# Altium schematic sheet Sheet2.SchDoc(Sheet2)
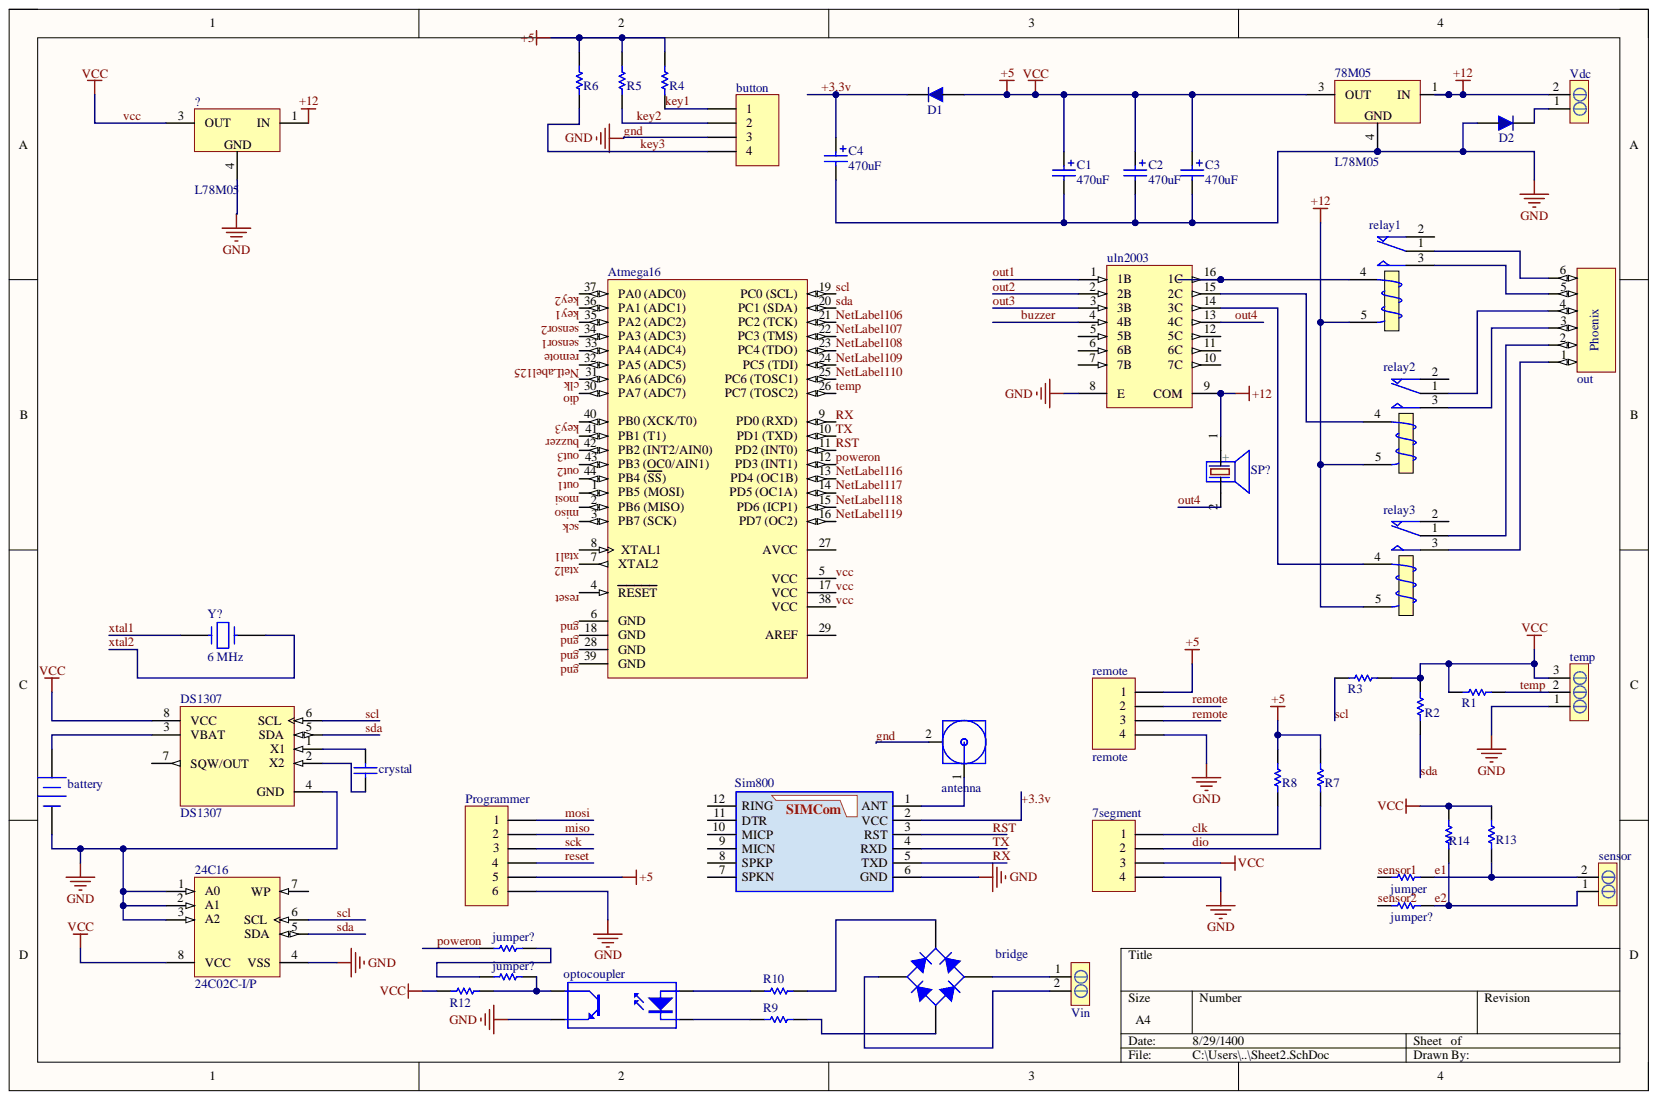
[diagram p0: Sheet2.SchDoc]

## Parts
7segment  7segment  [HDR1X4]
24C16  24C02C-I/P  [PDIP300-P8]
78M05  L78M05  [TO-252]
?  L78M05  [TO-252]
antenna  ANTENNA  [SMA-Right]  (value not drawn)
Atmega16  ATmega16-16AU  [44A_M]  (value not drawn)
battery  BATTERY 3V 1220  [BATT SMD]  (value not drawn)
bridge  Bridge KBU PACKAGE  [Bridge DB107 Package]  (value not drawn)
button  button  [HDR1X4]
C1  470uF  [CAP_POL5]
C2  470uF  [CAP_POL5]
C3  470uF  [CAP_POL5]
C4  470uF  [CAP_POL5]
crystal  22pF  [XTAL-DT26]  (value not drawn)
D1  1n4007  [DIOM4629X24N]  (value not drawn)
D2  1n4007  [DIOM4629X24N]  (value not drawn)
DS1307  DS1307  [DIP8]
jumper  10K  [AXIAL-0.2]  (value not drawn)
jumper?  10K  [AXIAL-0.2]  (value not drawn)
jumper?  10K  [AXIAL-0.2]  (value not drawn)
jumper?  10K  [AXIAL-0.2]  (value not drawn)
optocoupler  Optoisolator1  [DIP-4]  (value not drawn)
out  PHOENIX 6  [phonix 6]  (value not drawn)
Programmer  programmer  [HDR1X6]  (value not drawn)
R1  RES  [CC2012-0805]  (value not drawn)
R2  RES  [CC2012-0805]  (value not drawn)
R3  RES  [CC2012-0805]  (value not drawn)
R4  RES  [CC2012-0805]  (value not drawn)
R5  RES  [CC2012-0805]  (value not drawn)
R6  RES  [CC2012-0805]  (value not drawn)
R7  RES  [CC2012-0805]  (value not drawn)
R8  RES  [CC2012-0805]  (value not drawn)
R9  1K  [Axial 1W  7_0mm]  (value not drawn)
R10  1K  [Axial 1W  7_0mm]  (value not drawn)
R12  RES  [CC2012-0805]  (value not drawn)
R13  RES  [CC2012-0805]  (value not drawn)
R14  RES  [CC2012-0805]  (value not drawn)
relay1  (no value)  [Relay 18.85x15.35x15.70]
relay2  (no value)  [Relay 18.85x15.35x15.70]
relay3  (no value)  [Relay 18.85x15.35x15.70]
remote  remote  [HDR1X4]
sensor  PHONIX2  [PHONIX2]  (value not drawn)
Sim800  SIM800L  [SIM800L_L250W236H60]  (value not drawn)
SP?  (no value)  [BUZZER 7.62mm DC]
temp  PHONIX3  [PHONIX3]  (value not drawn)
uln2003  ULN2003AN  [N0016A]  (value not drawn)
Vdc  PHONIX2  [PHONIX2]  (value not drawn)
Vin  PHONIX2  [PHONIX2]  (value not drawn)
Y?  6 MHz  [My_Crystal]

## Nets
+12: 78M05.1 ?.1 relay1.5 relay2.5 relay3.5 SP?.1 uln2003.9(COM) Vdc.2
+3.3v: C4.1 D1.2 Sim800.2(VCC)
buzzer: Atmega16.41(PB1_(T1)) uln2003.4(4B)
clk: 7segment.1 Atmega16.31(PA6_(ADC6)) R8.1
dio: 7segment.2 Atmega16.30(PA7_(ADC7)) R7.1
e1: jumper.2 R13.1 sensor.2
e2: jumper?.2 R14.1 sensor.1
gnd: 7segment.4 24C16.1(A0) 24C16.2(A1) 24C16.3(A2) 24C16.4(VSS) 78M05.4 ?.4 antenna.2 Atmega16.6(GND) Atmega16.18(GND) Atmega16.28(GND) Atmega16.39(GND) battery.2 button.3 C1.2 C2.2 C3.2 C4.2 D2.1 DS1307.4(GND) optocoupler.3 Programmer.6 remote.4 Sim800.6(GND) temp.1 uln2003.8(E)
key1: Atmega16.36(PA1_(ADC1)) button.1 R4.1
key2: Atmega16.37(PA0_(ADC0)) button.2 R5.1
key3: Atmega16.40(PB0_(XCK/T0)) button.4 R6.1
miso: Atmega16.2(PB6_(MISO)) Programmer.2
mosi: Atmega16.1(PB5_(MOSI)) Programmer.1
NetLabel106: Atmega16.21(PC2_(TCK))
NetLabel107: Atmega16.22(PC3_(TMS))
NetLabel108: Atmega16.23(PC4_(TDO))
NetLabel109: Atmega16.24(PC5_(TDI))
NetLabel110: Atmega16.25(PC6_(TOSC1))
NetLabel116: Atmega16.13(PD4_(OC1B))
NetLabel117: Atmega16.14(PD5_(OC1A))
NetLabel118: Atmega16.15(PD6_(ICP1))
NetLabel119: Atmega16.16(PD7_(OC2))
NetLabel125: Atmega16.32(PA5_(ADC5))
out1: Atmega16.44(PB4_(SS)) uln2003.1(1B)
out2: Atmega16.43(PB3_(OC0/AIN1)) uln2003.2(2B)
out3: Atmega16.42(PB2_(INT2/AIN0)) uln2003.3(3B)
out4: SP?.2 uln2003.13(4C)
poweron: Atmega16.12(PD3_(INT1)) jumper?.1
remote: Atmega16.33(PA4_(ADC4)) remote.2 remote.3
reset: Atmega16.4(RESET) Programmer.4
RST: Atmega16.11(PD2_(INT0)) Sim800.3(RST)
RX: Atmega16.9(PD0_(RXD)) Sim800.5(TXD)
sck: Atmega16.3(PB7_(SCK)) Programmer.3
scl: 24C16.6(SCL) Atmega16.19(PC0_(SCL)) DS1307.6(SCL) R3.1
sda: 24C16.5(SDA) Atmega16.20(PC1_(SDA)) DS1307.5(SDA) R2.1
sensor1: Atmega16.34(PA3_(ADC3)) jumper.1
sensor2: Atmega16.35(PA2_(ADC2)) jumper?.1
temp: Atmega16.26(PC7_(TOSC2)) R1.2 temp.2
TX: Atmega16.10(PD1_(TXD)) Sim800.4(RXD)
vcc: 7segment.3 24C16.8(VCC) 78M05.3 ?.3 Atmega16.5(VCC) Atmega16.17(VCC) Atmega16.38(VCC) C1.1 C2.1 C3.1 D1.1 DS1307.8(VCC) Programmer.5 R1.1 R2.2 R3.2 R4.2 R5.2 R6.2 R7.2 R8.2 R12.2 R13.2 R14.2 remote.1 temp.3
xtal1: Atmega16.8(XTAL1) Y?.1
xtal2: Atmega16.7(XTAL2) Y?.2
Netantenna_1: antenna.1 Sim800.1(ANT)
Netbattery_1: battery.1 DS1307.3(VBAT)
Netbridge_1: bridge.1 R10.2
Netbridge_2: bridge.2 Vin.2
Netbridge_3: bridge.3 Vin.1
Netbridge_4: bridge.4 R9.2
Netcrystal_1: crystal.1 DS1307.1(X1)
Netcrystal_2: crystal.2 DS1307.2(X2)
NetD2_2: D2.2 Vdc.1
Netjumper?_1: jumper?.1 jumper?.2
Netjumper?_2: jumper?.2 optocoupler.4 R12.1
Netoptocoupler_1: optocoupler.1 R10.1
Netoptocoupler_2: optocoupler.2 R9.1
Netout_1: out.1 relay3.3
Netout_2: out.2 relay3.1
Netout_3: out.3 relay2.3
Netout_4: out.4 relay2.1
Netout_5: out.5 relay1.3
Netout_6: out.6 relay1.1
Netrelay1_4: relay1.4 uln2003.16(1C)
Netrelay2_4: relay2.4 uln2003.15(2C)
Netrelay3_4: relay3.4 uln2003.14(3C)

Not connected: 24C16 7(WP); Atmega16 27(AVCC) 29(AREF); DS1307 7(SQW/OUT); relay1 2; relay2 2; relay3 2; Sim800 7(SPKN) 8(SPKP) 9(MICN) 10(MICP) 11(DTR) 12(RING); uln2003 5(5B) 6(6B) 7(7B) 10(7C) 11(6C) 12(5C)

## Library data of the parts
7segment button remote = Header 4: Header, 4-Pin; Component Kind: Standard; LatestRevisionDate: 17-Jul-2002; LatestRevisionNote: Re-released for DXP Platform.; Library Name: Altium.IntLib; Signal Integrity: Connector; Publisher: Altium Limited
24C16 = CMP-0159-00018-1: 2Kbit, 400kHz, 5V, I2C Serial EEPROM, 8-Pin PDIP, Industrial Temperature; Code_JEDEC: MS-001; Component Kind: Standard; DatasheetVersion: revJ, Aug-2008; Library Name: Altium Content Vault; PackageDescription: 8-Lead Plastic Dual In-Line (P) - 300 mil Body [PDIP]; PackageReference: PDIP300-P8; PackageVersion: revBB, Aug-2009
78M05 ? = L78M05: Precision 500mA Regulator; Component Kind: Standard; Library Name: Altium.IntLib
Atmega16 = CMP-0095-00235-2: 8-bit AVR Microcontroller, 4.5-5.5V, 16KB Flash, 512 Bytes EEPROM, 1KB SRAM, 44-pin TQFP, Industrial Grade (-40°C to 85°C), Pb-Free; Code_JEDEC: MS-026-ACB; Component Kind: Standard; ComponentLink1Description: Manufacturer URL; ComponentLink1URL: http://www.atmel.com/; ComponentLink2Description: ATmega16(L)  Datasheet; ComponentLink2URL: http://www.atmel.com/dyn/resources/prod_documents/doc2466.pdf; ComponentLink3Description: ATmega16(L) Summary; ComponentLink3URL: http://www.atmel.com/dyn/resources/prod_documents/2466S.pdf; DatasheetVersion: revT, Jul-2010; Library Name: Altium Content Vault; PackageDescription: 44-Pin Plastic Thin Profile Quad Flat Pack, 10 x 10 x 1.0 mm Body, 0.8 mm Pitch (TQFP); PackageReference: 44A; PackageVersion: revC, Oct-2010; RevisionNote: DatasheetVersion & PackageVersion updated. URLs added.
C1-C4 = Cap Pol3: Polarized Capacitor (Surface Mount); Value: 100pF; Component Kind: Standard; Library Name: General_15.IntLib; Published: 8-Jun-2000; Revision: July-2002: Re-released for DXP Platform.; Publisher: Altium Limited
crystal = Cap Semi_1: Capacitor (Semiconductor SIM Model); Component Kind: Standard; LatestRevisionDate: 29-May-2009; LatestRevisionNote: IPC-7351 Footprint Added.; Library Name: Devices.IntLib; PackageDescription: Chip Capacitor; PackageReference: C1206; Published: 8-Jun-2000; Signal Integrity: Cap Semi; Simulation: CAP; Value: 22pF; Publisher: Altium Limited
D1 D2 = 1n4007 SMD M7: Standard Rectifiers; Manufacturer: DC COMPONENTS; Part. No: M7; Designer: Abbas Basereh; Component Kind: Standard; Data: 16-12-2016; Library Name: Altium.IntLib; Link: http://www.tme.eu/en/details/m7/smd-universal-diodes/dc-components/
DS1307 = DS1307: 64 X 8 Serial Real-Time Clock; Package Information: Latest Revision: 1999; Component Kind: Standard; Datasheet: Latest Revision: 10-Aug-1999; Library Name: General_15.IntLib; Package Reference: DIP8; Published: 13-Oct-2000; Revision: 17-Jul-2002: Re-released for DXP Platform.; Publisher: Altium Limited
jumper jumper? jumper? jumper? = Res1: Resistor; Value: 1K; Component Kind: Standard; Library Name: General_15.IntLib; Published: 8-Jun-2000; Revision: July-2002: Re-released for DXP Platform.; Publisher: Altium Limited
optocoupler = Optoisolator1: 4-Pin Phototransistor Optocoupler; Component Kind: Standard; DatasheetVersion: Nov-2003; LatestRevisionDate: 04-Mar-2005; LatestRevisionNote: Sch pins 3 & 4 Swapped.; Library Name: Miscellaneous Devices.IntLib; PackageReference: DIP-4; Published: 24-Mar-1999; Publisher: Altium Limited
Programmer = Header 6: Header, 6-Pin; Component Kind: Standard; LatestRevisionDate: 17-Jul-2002; LatestRevisionNote: Re-released for DXP Platform.; Library Name: Altium.IntLib; Signal Integrity: Connector; Publisher: Altium Limited
R1-R8 R12-R14 = RES: Resistor; Component Kind: Standard; DatasheetDocument: Nov-2002; Published: 5-Jan-2004; Library Name: Altium Nanoboard Project.IntLib; Publisher: Altium Limited
R9 R10 = RES Axial 1W: Designer: Abbas Basereh; Component Kind: Standard; Data: 4-1-2017; Desc.: RES Axial 1W; Description: RES Axial 1W; Library Name: General_14.IntLib
relay1-relay3 = RELAY_SPDT: Single-Pole Dual-Throw Relay; Designer: Abbas Basereh; Component Kind: Standard; ComponentLink1Description: Datasheet; Library Name: Altium.IntLib; Mounting Technology: Through-Hole; Pin Count: 0; Update Date: 2017-3-1
Sim800 = SIM800L: GSM/GPRS Module; Component Kind: Standard; Library Name: SIM800L.SchLib; Publisher: 3DFootprint.ir
SP? = PIEZO BUZZER: PIEZO BUZZER ACTIVE; Comment_1: SPEAKER AND BEEP; Designer: Abbas Basereh; Component Kind: Standard; ComponentLink2Description: Reference; Design Date: 1395/11/14; Library Name: Altium.IntLib; Mounting Technology: THD; PackageDescription: THD; Pin Count: 2; Status: Unreleased; Publisher: Abbas Basereh
uln2003 = CMP-2000-07352-1: High-Voltage, High-Current Darlington Transistor Array, 50 V, 500 mA, -20 to 70 degC, 16-Pin PDIP (N), Pb-Free (RoHS), Tube; Manufacturer: TexasInstruments; Configuration: Array7; Maximum Collector Emitter Saturation Voltage: 1.1@100mA; Maximum Collector Emitter Voltage: 50V; Package Version: 4040049/E, 12/2002; Peak DC Collector Current: 0.5A; Rad Hard: No; Category: DarlingtonBJT; Component Kind: Standard; Datasheet URL: http://www.ti.com/general/docs/lit/getliterature.tsp?genericPartNumber=uln2003a&fileType=pdf; Datasheet Version: Rev. O, 01/2016; Library Name: Altium Content Vault; Manufacturer URL: http://www.ti.com/; Mounting: ThroughHole; Mounting Technology: ThroughHole; Package: 16PDIP; Package Description: 16-Pin Plastic In-Line, Body 19.305 x 6.35 mm, Pitch 2.54 mm; Package Reference: N16; Package URL: http://www.ti.com/litv/pdf/mpdi002c; Packaging: Rail/Tube; Type: NPN
Y? = CRYSTAL: Component Kind: Standard; Description: Crystal; Library Name: Devices.IntLib
antenna = ANTENNA: Component Kind: Standard; Library Name: ZetaBoard Altium Lib 14000319.IntLib
battery = BATTERY 3V 1220: Component Kind: Standard; Library Name: General_04.IntLib
bridge = Bridge KBU PACKAGE: Component Kind: Standard; Description: Bridge KBU PACKAGE; Library Name: Bridge KBU PACKAGE.SchLib
out = PHOENIX 6: Component Kind: Standard; Library Name: General_04.IntLib
sensor Vdc Vin = PHONIX2: Component Kind: Standard; Library Name: General_20.IntLib
temp = PHONIX3: Component Kind: Standard; Library Name: General_20.IntLib
